# Altium schematic sheet Ruddock2_0_1_General.SchDoc
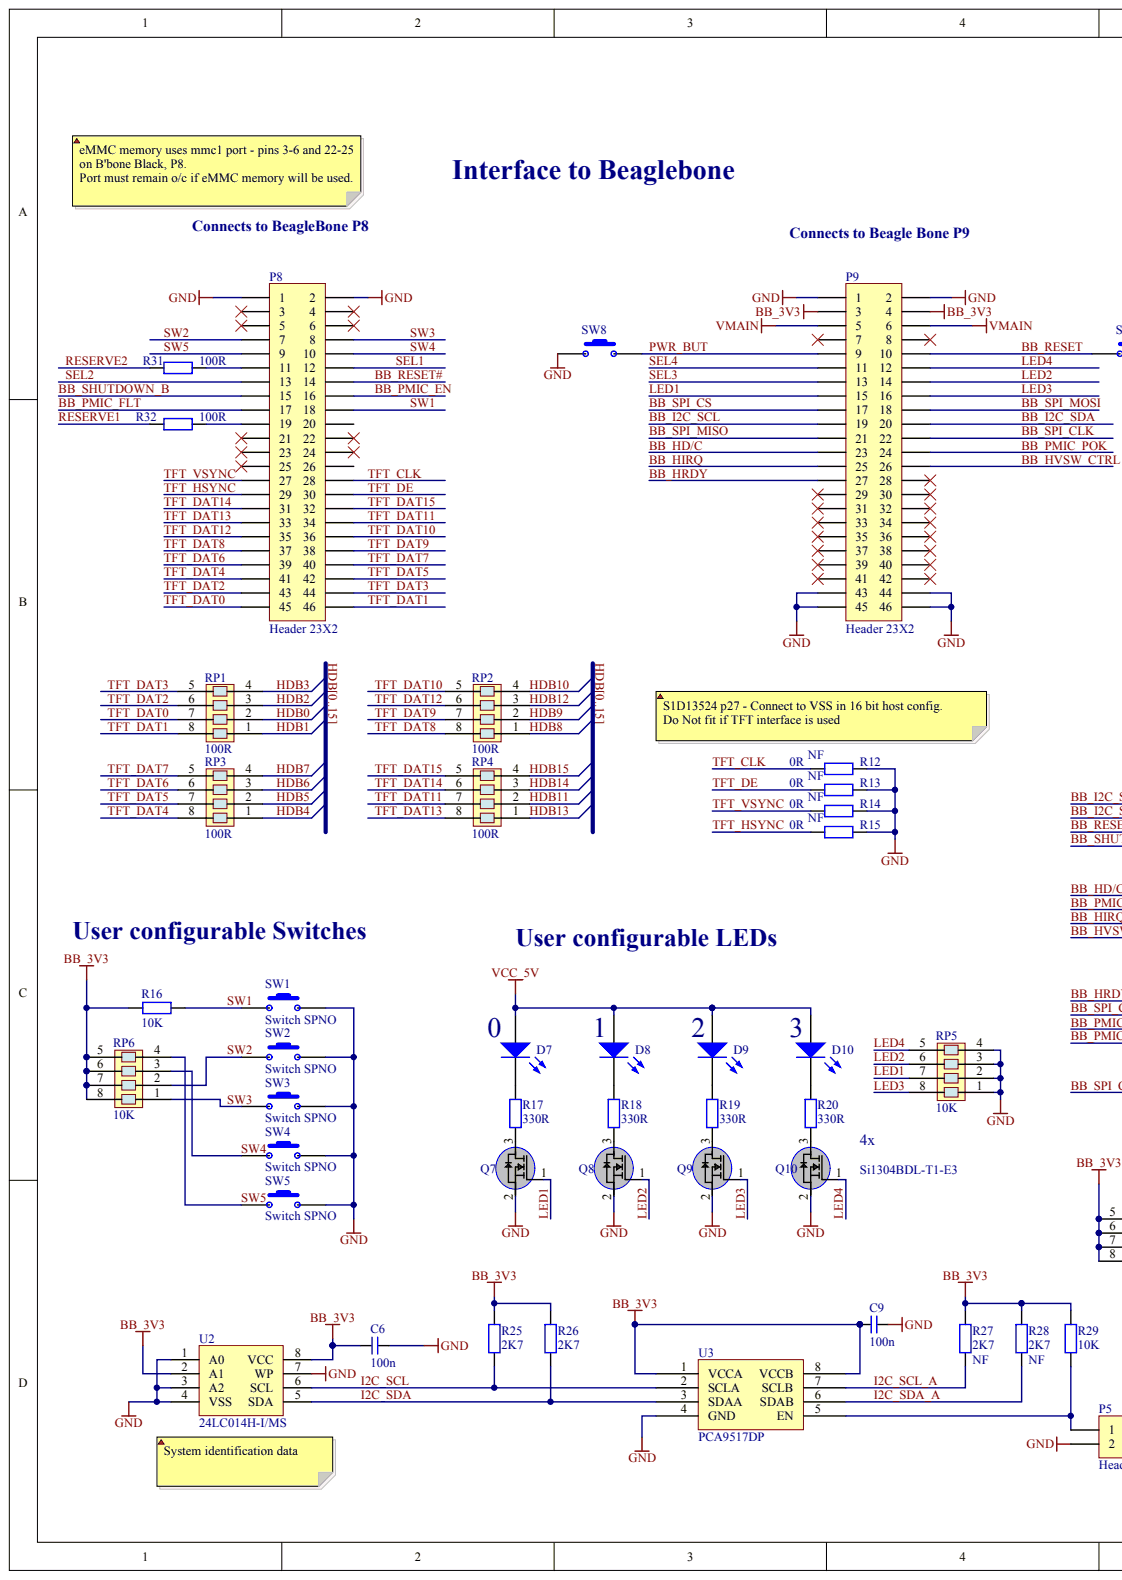
[diagram p0: Ruddock2_0_1_General.SchDoc, left]
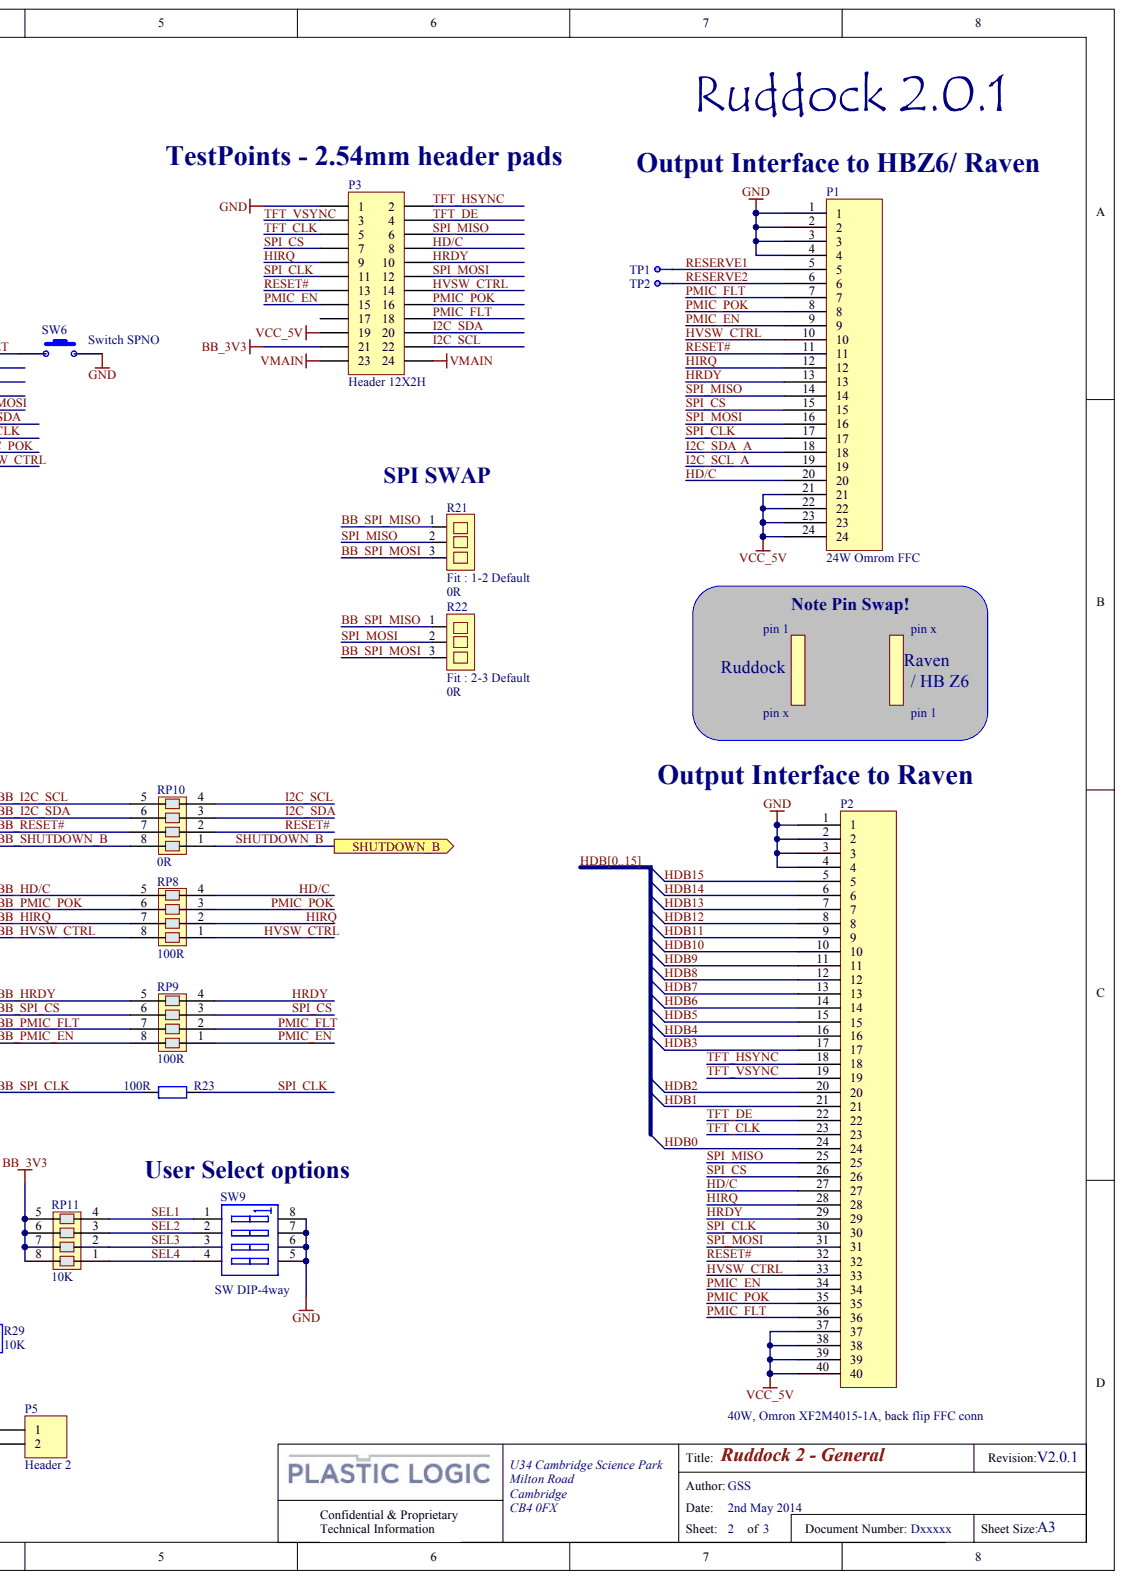
[diagram p1: Ruddock2_0_1_General.SchDoc, right]

## Parts
C6  100n
C9  100n
D7  LED2  (value not drawn)
D8  LED2  (value not drawn)
D9  LED2  (value not drawn)
D10  LED2
P1  24W Omrom FFC
P2  Manufacturer: Omron  (hidden comment: 40W, Omron XF2M4015-1A, back flip FFC conn)
P3  Header 12X2H
P5  Header 2
P8  Header 23X2
P9  Header 23X2
Q7  Si1304BDL-T1-E3
Q8  Si1304BDL-T1-E3
Q9  Si1304BDL-T1-E3
Q10  Si1304BDL-T1-E3
R12  Fitted: NF  (hidden comment: 0R)
R13  Fitted: NF  (hidden comment: 0R)
R14  Fitted: NF  (hidden comment: 0R)
R15  Fitted: NF  (hidden comment: 0R)
R16  10K
R17  330R
R18  330R
R19  330R
R20  330R
R21  Fit : 1-2 Default  value 0R
R22  Fit : 2-3 Default  value 0R
R23  100R
R25  2K7
R26  2K7
R27  Fitted: NF  (hidden comment: 2K7)
R28  Fitted: NF  (hidden comment: 2K7)
R29  10K
R31  100R
R32  100R
RP1  100R
RP2  100R
RP3  100R
RP4  100R
RP5  10K
RP6  10K
RP8  100R
RP9  100R
RP10  0R
RP11  10K
SW1  Switch SPNO
SW2  Switch SPNO
SW3  Switch SPNO
SW4  Switch SPNO
SW5  Switch SPNO
SW6  Switch SPNO
SW8  Switch SPNO
SW9  SW DIP-4way
TP1  Test Pad  (value not drawn)
TP2  Test Pad  (value not drawn)
U2  24LC014H-I/MS
U3  PCA9517DP

## Ports
SHUTDOWN_B

## Nets
BB_3V3: C6.2 C9.2 P3.21 P9.3 P9.4 R16.2 R25.1 R26.1 R27.1 R28.1 R29.2 RP6.5 RP6.6 RP6.7 RP6.8 RP11.5 RP11.6 RP11.7 RP11.8 U2.2(A1) U2.8(VCC) U3.1(VCCA) U3.8(VCCB)
GND: C6.1 C9.1 P1.1 P1.2 P1.3 P1.4 P2.1 P2.2 P2.3 P2.4 P3.1 P5.2 P8.1 P8.2 P9.1 P9.2 P9.43 P9.44 P9.45 P9.46 Q7.2 Q8.2 Q9.2 Q10.2 R12.2 R13.2 R14.2 R15.2 RP5.1 RP5.2 RP5.3 RP5.4 SW1.3 SW2.3 SW3.3 SW4.3 SW5.3 SW6.3 SW8.3 SW9.5 SW9.6 SW9.7 SW9.8 U2.1(A0) U2.3(A2) U2.4(VSS) U2.7(WP) U3.4(GND)
VCC_5V: D7.1 D8.1 D9.1 D10.1 P1.21 P1.22 P1.23 P1.24 P2.37 P2.38 P2.39 P2.40 P3.19
VMAIN: P3.23 P3.24 P9.5 P9.6
BB_HD/C: P9.23 RP8.5
BB_HIRQ: P9.25 RP8.7
BB_HRDY: P9.27 RP9.5
BB_HVSW_CTRL: P9.26 RP8.8
BB_I2C_SCL: P9.19 RP10.5
BB_I2C_SDA: P9.20 RP10.6
BB_PMIC_EN: P8.16 RP9.8
BB_PMIC_FLT: P8.17 RP9.7
BB_PMIC_POK: P9.24 RP8.6
BB_RESET: P9.10 SW6.1
BB_RESET#: P8.14 RP10.7
BB_SHUTDOWN_B: P8.15 RP10.8
BB_SPI_CLK: P9.22 R23.1
BB_SPI_CS: P9.17 RP9.6
BB_SPI_MISO: P9.21 R21.1 R22.1
BB_SPI_MOSI: P9.18 R21.3 R22.3
HD/C: P1.20 P2.27 P3.8 RP8.4
HDB0: P2.24 RP1.2  [also labelled HDB]
HDB1: P2.21 RP1.1  [also labelled HDB]
HDB2: P2.20 RP1.3  [also labelled HDB]
HDB3: P2.17 RP1.4  [also labelled HDB]
HDB4: P2.16 RP3.1  [also labelled HDB]
HDB5: P2.15 RP3.2  [also labelled HDB]
HDB6: P2.14 RP3.3  [also labelled HDB]
HDB7: P2.13 RP3.4  [also labelled HDB]
HDB8: P2.12 RP2.1  [also labelled HDB]
HDB9: P2.11 RP2.2  [also labelled HDB]
HDB10: P2.10 RP2.4  [also labelled HDB]
HDB11: P2.9 RP4.2  [also labelled HDB]
HDB12: P2.8 RP2.3  [also labelled HDB]
HDB13: P2.7 RP4.1  [also labelled HDB]
HDB14: P2.6 RP4.3  [also labelled HDB]
HDB15: P2.5 RP4.4  [also labelled HDB]
HIRQ: P1.12 P2.28 P3.9 RP8.2
HRDY: P1.13 P2.29 P3.10 RP9.4
HVSW_CTRL: P1.10 P2.33 P3.14 RP8.1
I2C_SCL: P3.22 R25.2 RP10.4 U2.6(SCL) U3.2(SCLA)
I2C_SCL_A: P1.19 R27.2 U3.7(SCLB)
I2C_SDA: P3.20 R26.2 RP10.3 U2.5(SDA) U3.3(SDAA)
I2C_SDA_A: P1.18 R28.2 U3.6(SDAB)
LED1: P9.15 Q7.1 RP5.7
LED2: P9.14 Q8.1 RP5.6
LED3: P9.16 Q9.1 RP5.8
LED4: P9.12 Q10.1 RP5.5
PMIC_EN: P1.9 P2.34 P3.15 RP9.1
PMIC_FLT: P1.7 P2.36 P3.18 RP9.2
PMIC_POK: P1.8 P2.35 P3.16 RP8.3
PWR_BUT: P9.9 SW8.1
RESERVE1: P1.5 R32.1 TP1.1
RESERVE2: P1.6 R31.1 TP2.1
RESET#: P1.11 P2.32 P3.13 RP10.2
SEL1: P8.12 RP11.4 SW9.1
SEL2: P8.13 RP11.3 SW9.2
SEL3: P9.13 RP11.2 SW9.3
SEL4: P9.11 RP11.1 SW9.4
SHUTDOWN_B: RP10.1 ; port SHUTDOWN_B
SPI_CLK: P1.17 P2.30 P3.11 R23.2
SPI_CS: P1.15 P2.26 P3.7 RP9.3
SPI_MISO: P1.14 P2.25 P3.6 R21.2
SPI_MOSI: P1.16 P2.31 P3.12 R22.2
SW1: P8.18 R16.1 SW1.1
SW2: P8.7 RP6.2 SW2.1
SW3: P8.8 RP6.1 SW3.1
SW4: P8.10 RP6.3 SW4.1
SW5: P8.9 RP6.4 SW5.1
TFT_CLK: P2.23 P3.5 P8.28 R12.1
TFT_DAT0: P8.45 RP1.7
TFT_DAT1: P8.46 RP1.8
TFT_DAT2: P8.43 RP1.6
TFT_DAT3: P8.44 RP1.5
TFT_DAT4: P8.41 RP3.8
TFT_DAT5: P8.42 RP3.7
TFT_DAT6: P8.39 RP3.6
TFT_DAT7: P8.40 RP3.5
TFT_DAT8: P8.37 RP2.8
TFT_DAT9: P8.38 RP2.7
TFT_DAT10: P8.36 RP2.5
TFT_DAT11: P8.34 RP4.7
TFT_DAT12: P8.35 RP2.6
TFT_DAT13: P8.33 RP4.8
TFT_DAT14: P8.31 RP4.6
TFT_DAT15: P8.32 RP4.5
TFT_DE: P2.22 P3.4 P8.30 R13.1
TFT_HSYNC: P2.18 P3.2 P8.29 R15.1
TFT_VSYNC: P2.19 P3.3 P8.27 R14.1
NetD7_2: D7.2 R17.1
NetD8_2: D8.2 R18.1
NetD9_2: D9.2 R19.1
NetD10_2: D10.2 R20.1
NetP5_1: P5.1 R29.1 U3.5(EN)
NetP8_11: P8.11 R31.2
NetP8_19: P8.19 R32.2
NetQ7_3: Q7.3 R17.2
NetQ8_3: Q8.3 R18.2
NetQ9_3: Q9.3 R19.2
NetQ10_3: Q10.3 R20.2

Not connected: P3 17; P8 3 4 5 6 20 21 22 23 24 25 26; P9 7 8 28 29 30 31 32 33 34 35 36 37 38 39 40 41 42

## Library data of the parts
C6 C9 = (no symbol name): Manufacturer: AVX; ManufacturerPN: 06033C104KAZ2A; Fitted: I; Order Code: 183 3840; Tolerance: *; Value: 100n; Supplier: Farnell
D7-D10 = (no symbol name): Manufacturer: KINGBRIGHT; ManufacturerPN: KP-1608MGC; Value: LED GREEN; Order Code: 852-9825; Fitted: I; Supplier: Farnell
P1 = (no symbol name): Manufacturer: Omron; ManufacturerPN: XF2M-2415-1A; Fitted: I; Value: 20W, Omron XF2M2415-1A, back flip FFC conn; Order Code: 1112559; Supplier: Farnell
P2 = (no symbol name): Fitted: I; Order Code: 1112562; Value: 40W, Omron XF2M4015-1A, back flip FFC conn; ManufacturerPN: XF2M4015-1A; Supplier: Farnell
P3 = (no symbol name): Manufacturer: Samtec; ManufacturerPN: HTSW-112-05-F-D; Value: HTSW-112-05-F-D; Fitted: I; Order Code: HTSW-112-05-F-D; Supplier: Toby Electronics
P5 = (no symbol name): Manufacturer: Samtec; ManufacturerPN: HTSW-102-07-S-S; Fitted: I; Value: HTSW-102-07-S-S; Order Code: HTSW-102-07-S-S; Supplier: Toby Electronics
P8 P9 = (no symbol name): Manufacturer: Samtec; Fitted: I; Value: TSM-123-02-L-DV-A; Supplier: Toby Electronics; ManufacturerPN: TSM-123-02-L-DV-A; Order Code: TSM-123-02-L-DV-A
Q7-Q10 = (no symbol name): Manufacturer: Vishay-Siliconix; Fitted: I; Order Code: SI1304BDL-T1-E3CT-ND; Value: Si1304BDL-T1-E3; ManufacturerPN: Si1304BDL-T1-E3; Supplier: Digi-Key
R12-R15 = (no symbol name): Manufacturer: VISHAY DRALORIC; ManufacturerPN: CRCW0603100RFKEA; Order Code: 1469752; Tolerance: *; Value: 0R; Supplier: Farnell
R16 R29 = (no symbol name): Manufacturer: VISHAY DRALORIC; ManufacturerPN: CRCW060310K0FKEA; Tolerance: 1%; Fitted: I; Order Code: 1469748; Value: 10K; Supplier: Farnell
R17-R20 = (no symbol name): Manufacturer: VISHAY DRALORIC; ManufacturerPN: CRCW0603330RFKEA; Tolerance: 1%; Fitted: I; Order Code: 1469803; Value: 330R; Supplier: Farnell
R21 = (no symbol name): Manufacturer: VISHAY DRALORIC; ManufacturerPN: CRCW0603100RFKEA; Fitted: I; Value: 0R; Order Code: 1469752; Supplier: Farnell
R22 = (no symbol name): Manufacturer: VISHAY DRALORIC; ManufacturerPN: CRCW0603100RFKEA; Fitted: I; Order Code: 1469752; Supplier: Farnell
R23 R31 R32 = (no symbol name): Manufacturer: MULTICOMP; ManufacturerPN: MC0063W06031100R; Tolerance: 1%; Fitted: I; Order Code: 9330364; Value: 100R; Supplier: Farnell
R25 R26 = (no symbol name): Manufacturer: VISHAY DRALORIC; ManufacturerPN: CRCW06032K70FKEA; Tolerance: 1%; Fitted: I; Order Code: 1469768; Value: 2K7; Supplier: Farnell
R27 R28 = (no symbol name): Manufacturer: VISHAY DRALORIC; ManufacturerPN: CRCW06032K70FKEA; Tolerance: 1%; Order Code: 1469768; Value: 2K7; Supplier: Farnell
RP1-RP4 RP8 RP9 = (no symbol name): Manufacturer: Panasonic; ManufacturerPN: EXB28VR101JX; Fitted: I; Order Code: 2060044RL; Value: 100R; Supplier: Farnell
RP5 RP6 RP11 = (no symbol name): Manufacturer: Panasonic; ManufacturerPN: EXB28VR103JX; Fitted: I; Order Code: 2060058RL; Value: 10K; Supplier: Farnell
RP10 = (no symbol name): Manufacturer: Panasonic; ManufacturerPN: EXB18VR000X; Fitted: I; Order Code: 2060114; Value: 0R; Supplier: Farnell
SW1-SW6 SW8 = (no symbol name): Manufacturer: TE CONNECTIVITY / ALCOSWITCH; ManufacturerPN: FSM6JSMA; Order Code: 1555991; Fitted: I; Value: Tact sw, SPNO; Supplier: Farnell
SW9 = (no symbol name): Manufacturer: Mulitcomp; ManufacturerPN: MCEMR-04-T; Fitted: I; Order Code: 1524007; Value: Dip Switch 4sw, SMD, SPST; Supplier: Farnell
TP1 TP2 = (no symbol name): ManufacturerPN: PCB FEATURE; Fitted: I
U2 = (no symbol name): Manufacturer: Microchip; Fitted: I; Order Code: 1659737; Value: 24LC014; ManufacturerPN: 24LC014H-I/MS; Supplier: Farnell
U3 = (no symbol name): Manufacturer: NXP; Fitted: I; Order Code: 2101307; ManufacturerPN: PCA9517DP; Value: PCA9517DP; Supplier: Farnell
